# Altium schematic sheet Motor.SchDoc(Motor)
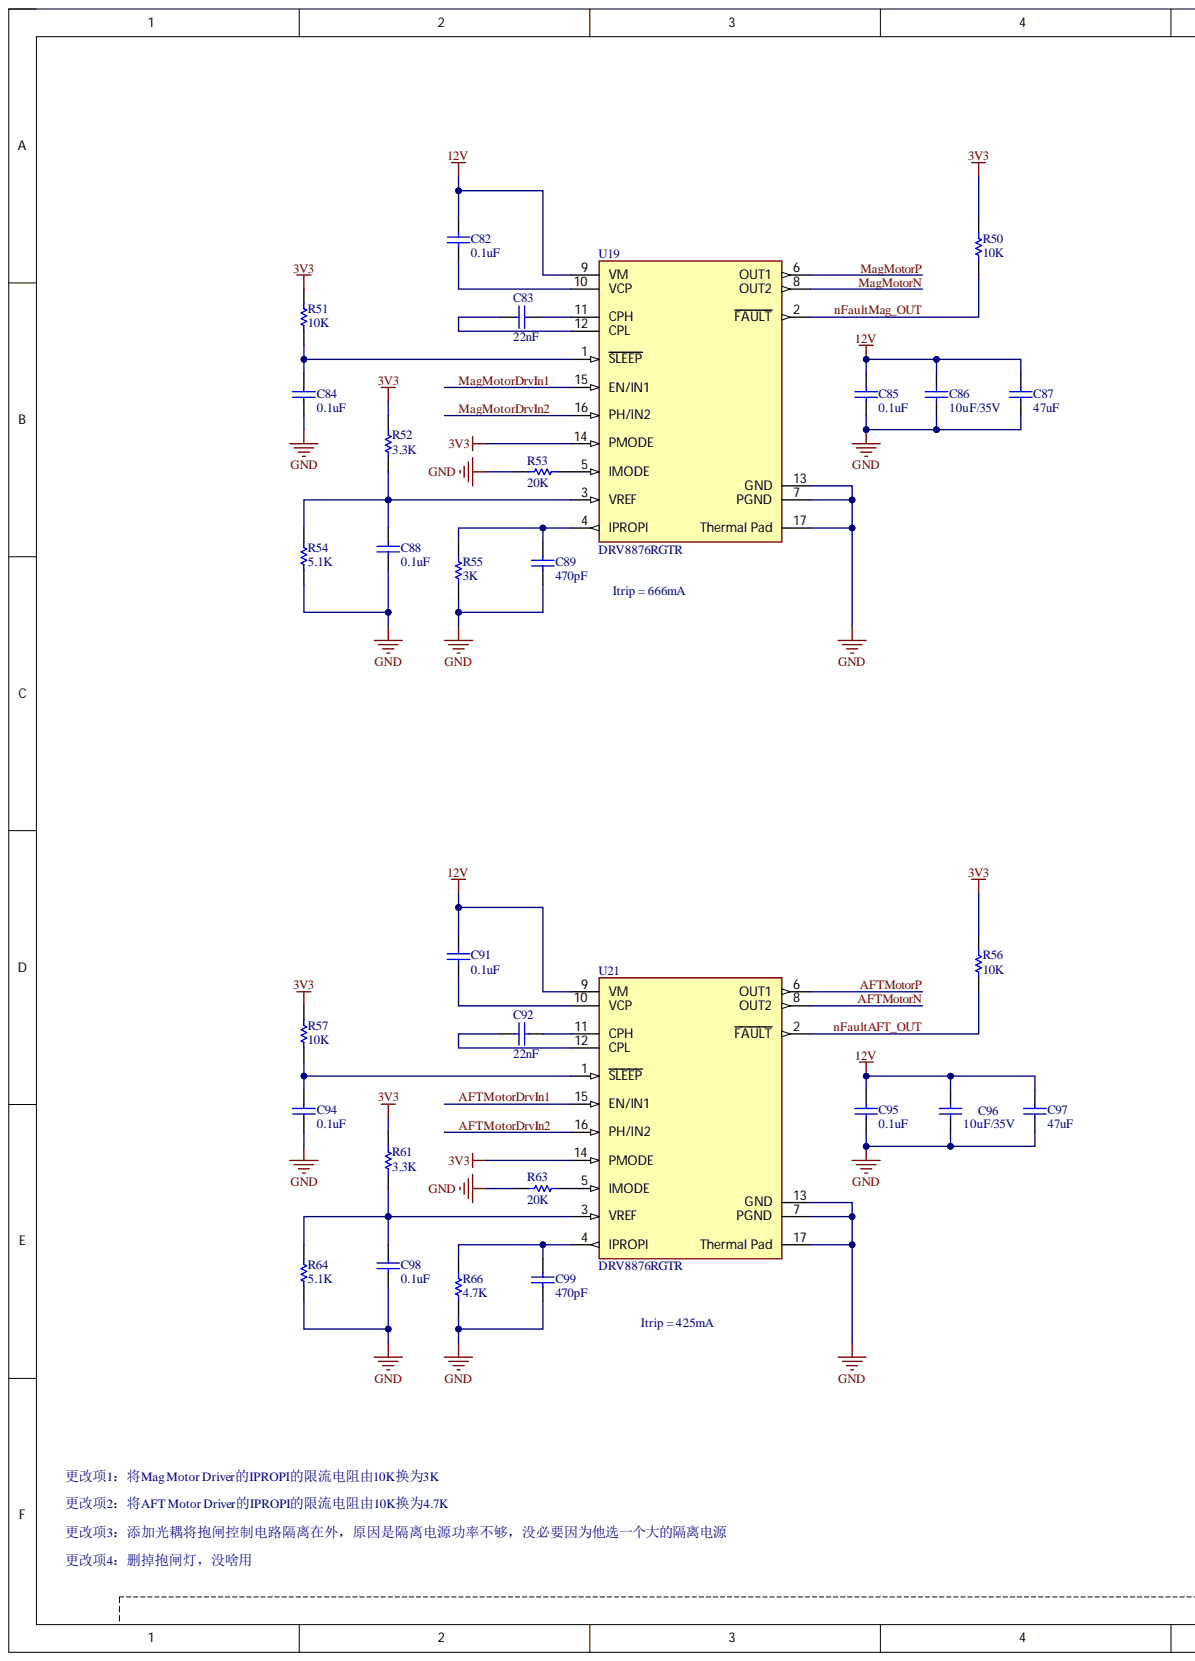
[diagram p0: Motor.SchDoc, left]
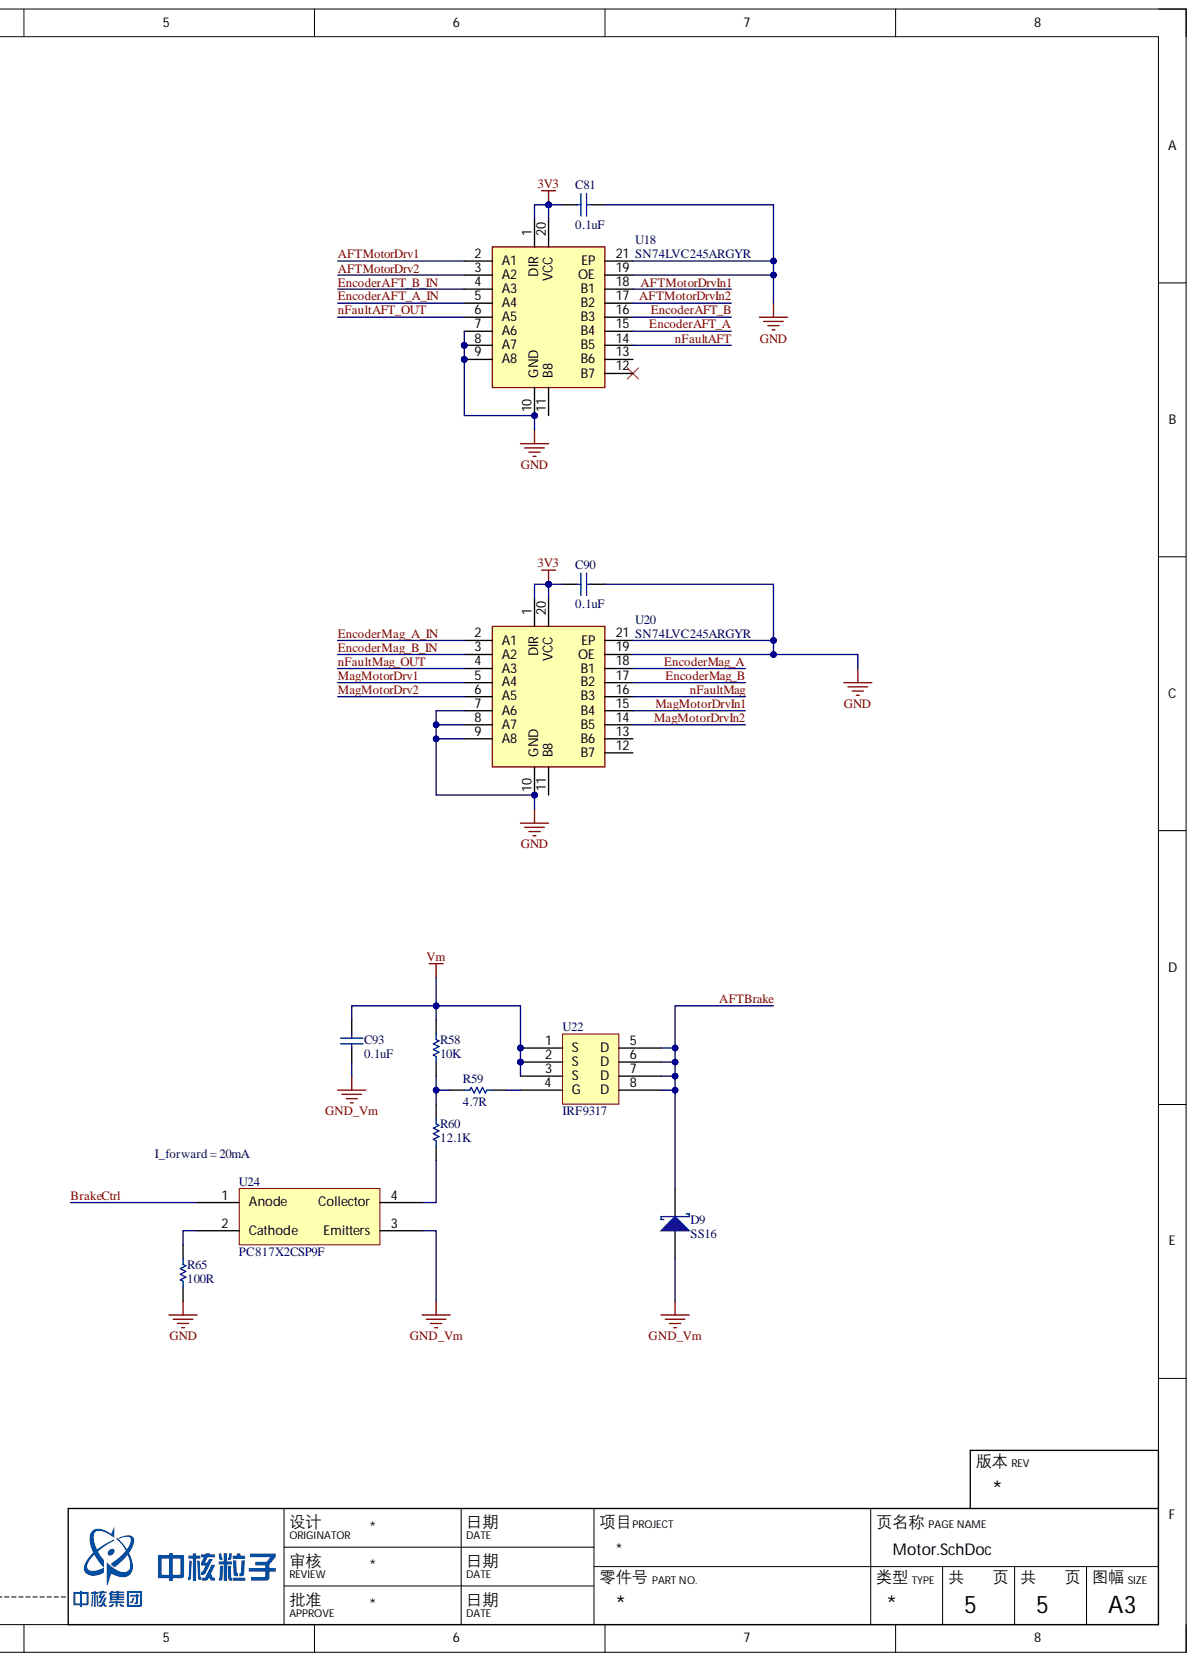
[diagram p1: Motor.SchDoc, right]

## Parts
C81  0.1uF  [C0402(1005)]
C82  0.1uF  [C0402(1005)]
C83  22nF  [C0402(1005)]
C84  0.1uF  [C0402(1005)]
C85  0.1uF  [C0402(1005)]
C86  10uF/35V  [C1206(3216)]
C87  47uF  [C1206(3216)]
C88  0.1uF  [C0402(1005)]
C89  470pF  [C0402(1005)]
C90  0.1uF  [C0402(1005)]
C91  0.1uF  [C0402(1005)]
C92  22nF  [C0402(1005)]
C93  0.1uF  [C0603(1608)]
C94  0.1uF  [C0402(1005)]
C95  0.1uF  [C0402(1005)]
C96  10uF/35V  [C1206(3216)]
C97  47uF  [C1206(3216)]
C98  0.1uF  [C0402(1005)]
C99  470pF  [C0402(1005)]
D9  SS16  [SMA-2_B_V]
R50  10K  [R0402(1005)]
R51  10K  [R0402(1005)]
R52  3.3K  [R0402(1005)]
R53  20K  [R0402(1005)]
R54  5.1K  [R0402(1005)]
R55  3K  [R0402(1005)]
R56  10K  [R0402(1005)]
R57  10K  [R0402(1005)]
R58  10K  [R0603(1608)]
R59  4.7R  [R0603(1608)]
R60  12.1K  [R0603(1608)]
R61  3.3K  [R0402(1005)]
R63  20K  [R0402(1005)]
R64  5.1K  [R0402(1005)]
R65  100R  [R0603(1608)]
R66  4.7K  [R0402(1005)]
U18  SN74LVC245ARGYR  [SN74LVC245ARGYR]
U19  DRV8876RGTR  [FP-RGT0016C-IPC_C]
U20  SN74LVC245ARGYR  [SN74LVC245ARGYR]
U21  DRV8876RGTR  [FP-RGT0016C-IPC_C]
U22  IRF9317  [SOIC8_N]
U24  PC817X2CSP9F  [SMD-4P]

## Nets
3V3: C81.1 C90.1 R50.2 R51.2 R52.2 R56.2 R57.2 R61.2 U18.1(DIR) U18.20(VCC) U19.14(PMODE) U20.1(DIR) U20.20(VCC) U21.14(PMODE)
12V: C82.2 C85.2 C86.2 C87.2 C91.2 C95.2 C96.2 C97.2 U19.9(VM) U21.9(VM)
GND: C81.2 C84.1 C85.1 C86.1 C87.1 C88.1 C89.1 C90.2 C94.1 C95.1 C96.1 C97.1 C98.1 C99.1 R53.1 R54.1 R55.1 R63.1 R64.1 R65.1 R66.1 U18.7(A6) U18.8(A7) U18.9(A8) U18.10(GND) U18.19(OE) U18.21(EP) U19.7(PGND) U19.13(GND) U19.17(Thermal_Pad) U20.7(A6) U20.8(A7) U20.9(A8) U20.10(GND) U20.19(OE) U20.21(EP) U21.7(PGND) U21.13(GND) U21.17(Thermal_Pad)
GND_Vm: C93.1 D9.1 U24.3(Emitters)
Vm: C93.2 R58.2 U22.1(S) U22.2(S) U22.3(S)
AFTBrake: D9.2 U22.5(D) U22.6(D) U22.7(D) U22.8(D)
AFTMotorDrv1: U18.2(A1)
AFTMotorDrv2: U18.3(A2)
AFTMotorDrvIn1: U18.18(B1) U21.15(EN/IN1)
AFTMotorDrvIn2: U18.17(B2) U21.16(PH/IN2)
AFTMotorN: U21.8(OUT2)
AFTMotorP: U21.6(OUT1)
BrakeCtrl: U24.1(Anode)
EncoderAFT_A: U18.15(B4)
EncoderAFT_A_IN: U18.5(A4)
EncoderAFT_B: U18.16(B3)
EncoderAFT_B_IN: U18.4(A3)
EncoderMag_A: U20.18(B1)
EncoderMag_A_IN: U20.2(A1)
EncoderMag_B: U20.17(B2)
EncoderMag_B_IN: U20.3(A2)
MagMotorDrv1: U20.5(A4)
MagMotorDrv2: U20.6(A5)
MagMotorDrvIn1: U19.15(EN/IN1) U20.15(B4)
MagMotorDrvIn2: U19.16(PH/IN2) U20.14(B5)
MagMotorN: U19.8(OUT2)
MagMotorP: U19.6(OUT1)
nFaultAFT: U18.14(B5)
nFaultAFT_OUT: R56.1 U18.6(A5) U21.2(FAULT)
nFaultMag: U20.16(B3)
nFaultMag_OUT: R50.1 U19.2(FAULT) U20.4(A3)
NetC82_1: C82.1 U19.10(VCP)
NetC83_1: C83.1 U19.11(CPH)
NetC83_2: C83.2 U19.12(CPL)
NetC84_2: C84.2 R51.1 U19.1(SLEEP)
NetC88_2: C88.2 R52.1 R54.2 U19.3(VREF)
NetC89_2: C89.2 R55.2 U19.4(IPROPI)
NetC91_1: C91.1 U21.10(VCP)
NetC92_1: C92.1 U21.11(CPH)
NetC92_2: C92.2 U21.12(CPL)
NetC94_2: C94.2 R57.1 U21.1(SLEEP)
NetC98_2: C98.2 R61.1 R64.2 U21.3(VREF)
NetC99_2: C99.2 R66.2 U21.4(IPROPI)
NetR53_2: R53.2 U19.5(IMODE)
NetR58_1: R58.1 R59.1 R60.2
NetR59_2: R59.2 U22.4(G)
NetR60_1: R60.1 U24.4(Collector)
NetR63_2: R63.2 U21.5(IMODE)
NetR65_2: R65.2 U24.2(Cathode)

Not connected: U18 11(B8) 12(B7) 13(B6); U20 11(B8) 12(B7) 13(B6)

## Library data of the parts
C81 C90 = Cap 0.1uF 0402-50V: ceramic 50V; 价格: ¥0.375@100; 制造商: TDK; 型号: C1005X7R1H104K050BB; Component Kind: Standard; Library Name: RCL_release.SchLib; 供应商及库存编号: e络盟 2525047
C82 C84 C85 C88 C91 C94 C95 C98 = Cap 0.1uF 0402-25V: ceramic 25V; 价格: ¥0.33@100; 制造商: KEMET; 型号: C0402C104M3RACTU; Component Kind: Standard; Library Name: RCL_release.SchLib; 供应商及库存编号: e络盟 2528342
C83 C92 = Cap 22nF 0402-25V: ceramic 25V; 价格: ¥0.34@100; 制造商: KEMET; 型号: C0402C223K3RACTU; Component Kind: Standard; Library Name: RCL_release.SchLib; 供应商及库存编号: e络盟 2522384
C86 C96 = Cap 10 uF 1206（3216）: ceramic 35V; 价格: ¥1.32@1; 制造商: MURATA; 名称: 瓷片电容; 型号: GRM31CR6YA106KA12L; Component Kind: Standard; Library Name: RCL_release.SchLib; 供应商及库存编号: e络盟 1797011
C87 C97 = Cap 47uF 1206-25V: MLCC,47uF,25V; 价格: ¥3.1@100; 制造商: TDK; 名称: 瓷片电容; 型号: C3216X5R1E476M160AC; Component Kind: Standard; Library Name: RCL_release.SchLib; 供应商及库存编号: 一博 C0000704
C89 C99 = Cap 470pF 0402-50V: ceramic 50V; Component Kind: Standard; Library Name: RCL_release.SchLib
C93 = Cap 0.1uF 0603-50V: ceramic 50V; 价格: ¥0.44@100; 制造商: WURTH ELEKTRONIK; 型号: 885012206095; Component Kind: Standard; Library Name: RCL_release.SchLib; 供应商及库存编号: e络盟 2495187
D9 = SS16: 标准恢复二极管, 60 V 1 A; 价格: CNY0.30@25; 制造商: MULTICOMP; Component Kind: Standard; Library Name: Transistor_release.SchLib; 供应商及库存编号: e络盟 2101190; 型号: SS16
R50 R51 R56 R57 = Res 10K 0402: Res ±1%; Component Kind: Standard; Library Name: RCL_release.SchLib
R52 R61 = Res 3.3K 0402: Res ±1%; Component Kind: Standard; Library Name: RCL_release.SchLib
R53 R63 = Res 20K 0402: Res ±1%; Component Kind: Standard; Library Name: RCL_release.SchLib
R54 R64 = Res 5.1K 0402: Res ±1%; Component Kind: Standard; Library Name: RCL_release.SchLib
R55 = Res 3K 0402: Res ±1%; Component Kind: Standard; Library Name: RCL_release.SchLib
R58 = Res 10K 0603: Res ±1%; 名称: 贴片电阻; Component Kind: Standard; Library Name: RCL_release.SchLib
R59 = Res 4.7R 0603: Res 4.7R 0603 1%; Component Kind: Standard; Library Name: RCL_temp.SchLib
R60 = Res 12.1K 0603: Res ±1%; Component Kind: Standard; Library Name: RCL_release.SchLib
R65 = Res 100R 0603: Res ±1%; Component Kind: Standard; Library Name: RCL_release.SchLib
R66 = Res 4.7K 0402: Res ±1%; Component Kind: Standard; Library Name: RCL_release.SchLib
U18 U20 = SN74LVC245ARGYR: Octal Bus Transceiver With 3-State Outputs; Component Kind: Standard; Library Name: IC_release.SchLib
U19 U21 = DRV8876RGTR: Motor/Motion/Ignition Controllers & Drivers Automotive adjustable 1-A low-dropout (LDO) linear regulator with power good 6-WSON -40 to 125; Component Kind: Standard; Library Name: IC_release.SchLib
U22 = IRF9317: MOSFET, P沟道, -30V, -16A, SOIC; 价格: ￥5.29@100; 制造商: INFINEON; 型号: IRF9317TRPBF.; Component Kind: Standard; Library Name: Transistor_release.SchLib; 供应商及库存编号: digikey IRF9317TRPBFCT-ND
U24 = PC817X2CSP9F: photocoupler sharp buffer logic; Component Kind: Standard; Library Name: IC_temp.SchLib
